# Altium schematic sheet Motor_Controller.SchDoc
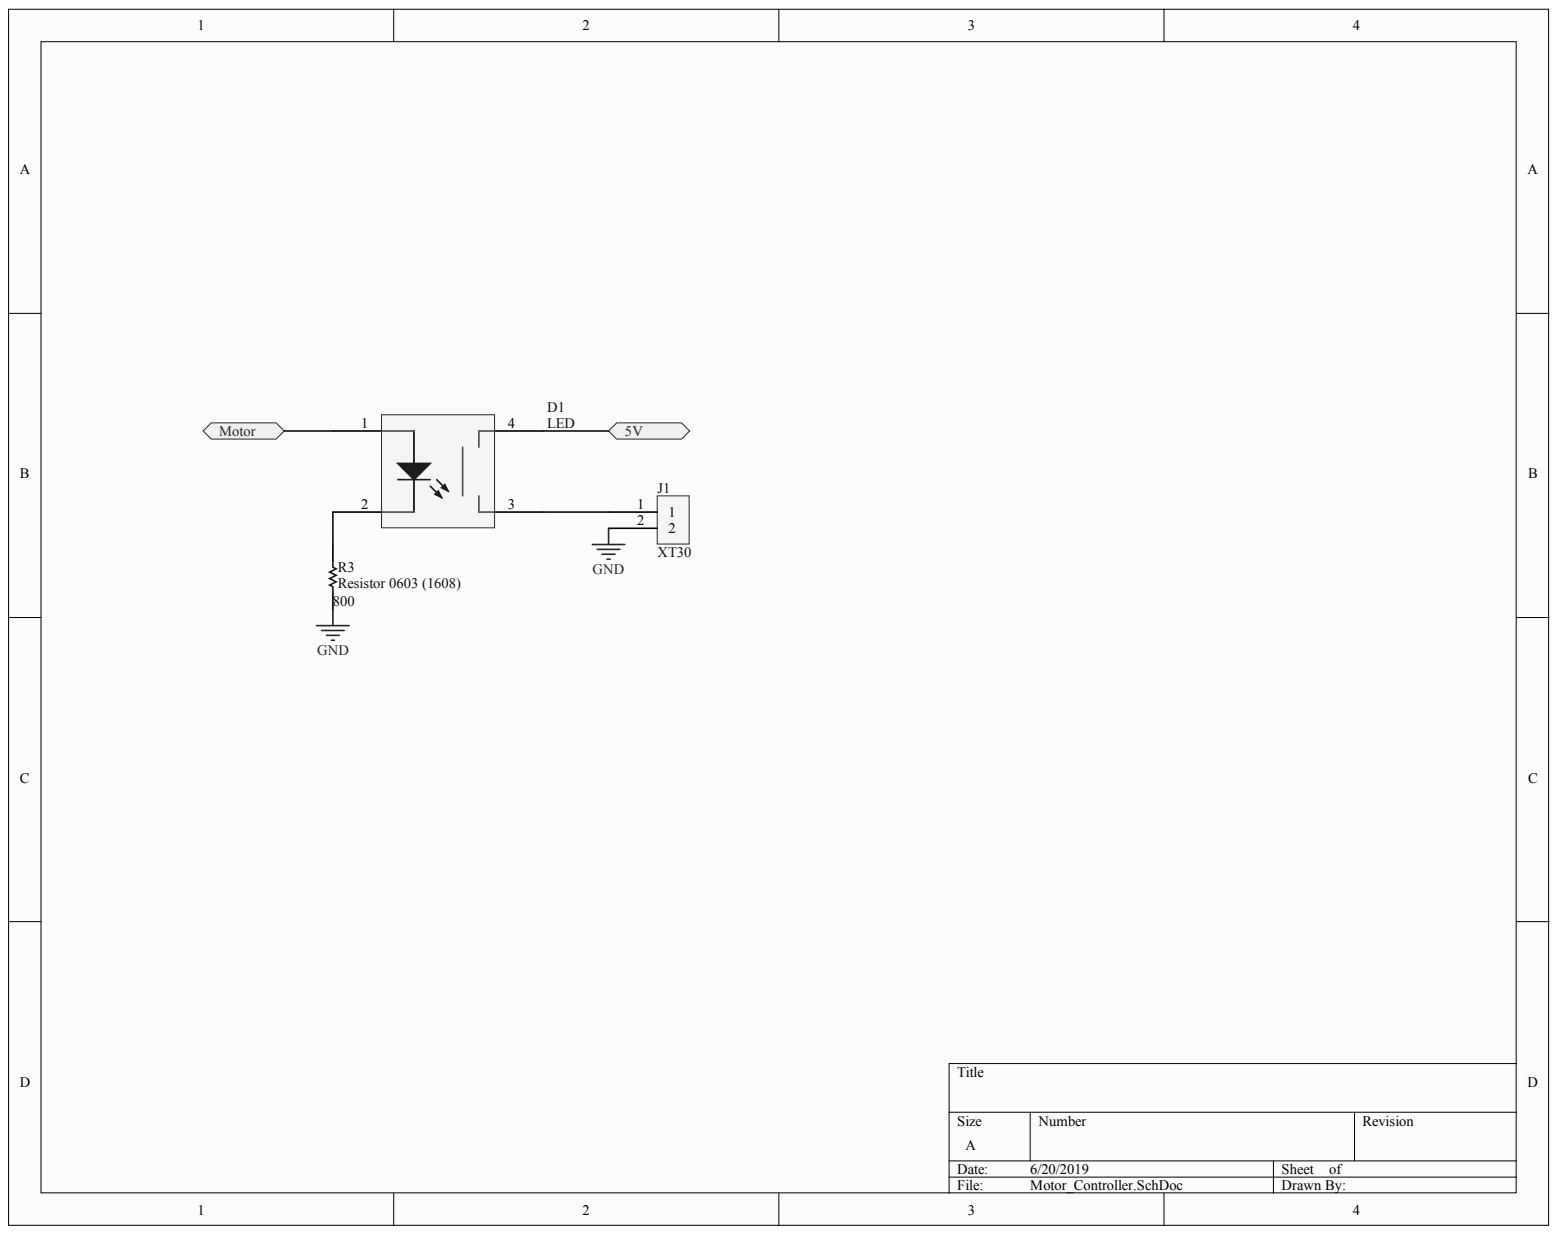
[diagram p0: Motor_Controller.SchDoc]

## Parts
D1  LED  [PCB-lw6lh1gucxwakl43fe8c-1]
J1  XT30  [PCB-8h6goupi0sbkduz6cbnu-1]
R3  Resistor 0603 (1608)  [PCB-8xtmhdk62wywm3ayihyv-1]

## Ports
5V, MOTOR

## Nets
GND: J1.2 R3.1
MOTOR: D1.1 ; port MOTOR
5V: D1.4 ; port 5V
NetD1_2: D1.2 R3.2
NetD1_3: D1.3 J1.1

Not connected: D1 A K

## Library data of the parts
D1 = CMP-a5f06cd907587a37-5: PHOTOREL IR EMIT DIOD+PHOTMOSFET 4PINDIP; Operating Temperature Range: -40°C to +85°C; Case Style: DIP4; Forward Curr...; Component Kind: Standard; Library Name: CommunityVault; OctopartID: a5f06cd907587a37; RoHS: Compliant
J1 = CMP-nlx8yci0umf6n9sk0ty3-5: Very similar to the XT60 models, but smaller and made for 30A; Component Kind: Standard; CustomComponentID: nlx8yci0umf6n9sk0ty3; Homepage URL: https://www.amazon.com/ProgressiveRC-AC-XT30-XT30-Connectors-pairs/dp/B011WDZGM0; Library Name: FavoritesLibrary
R3 = CMP-8d0d5kw4b9o869c0if8y-1: Generic Resistor pad 0603 Imperial and 1608 Metric; Resistance: 800; Case/Package: 0603 (1608); Component Kind: Standard; CustomComponentID: 8d0d5kw4b9o869c0if8y; Library Name: CommunityVault
